# Altium schematic sheet All-in-one-PCB.SchDoc
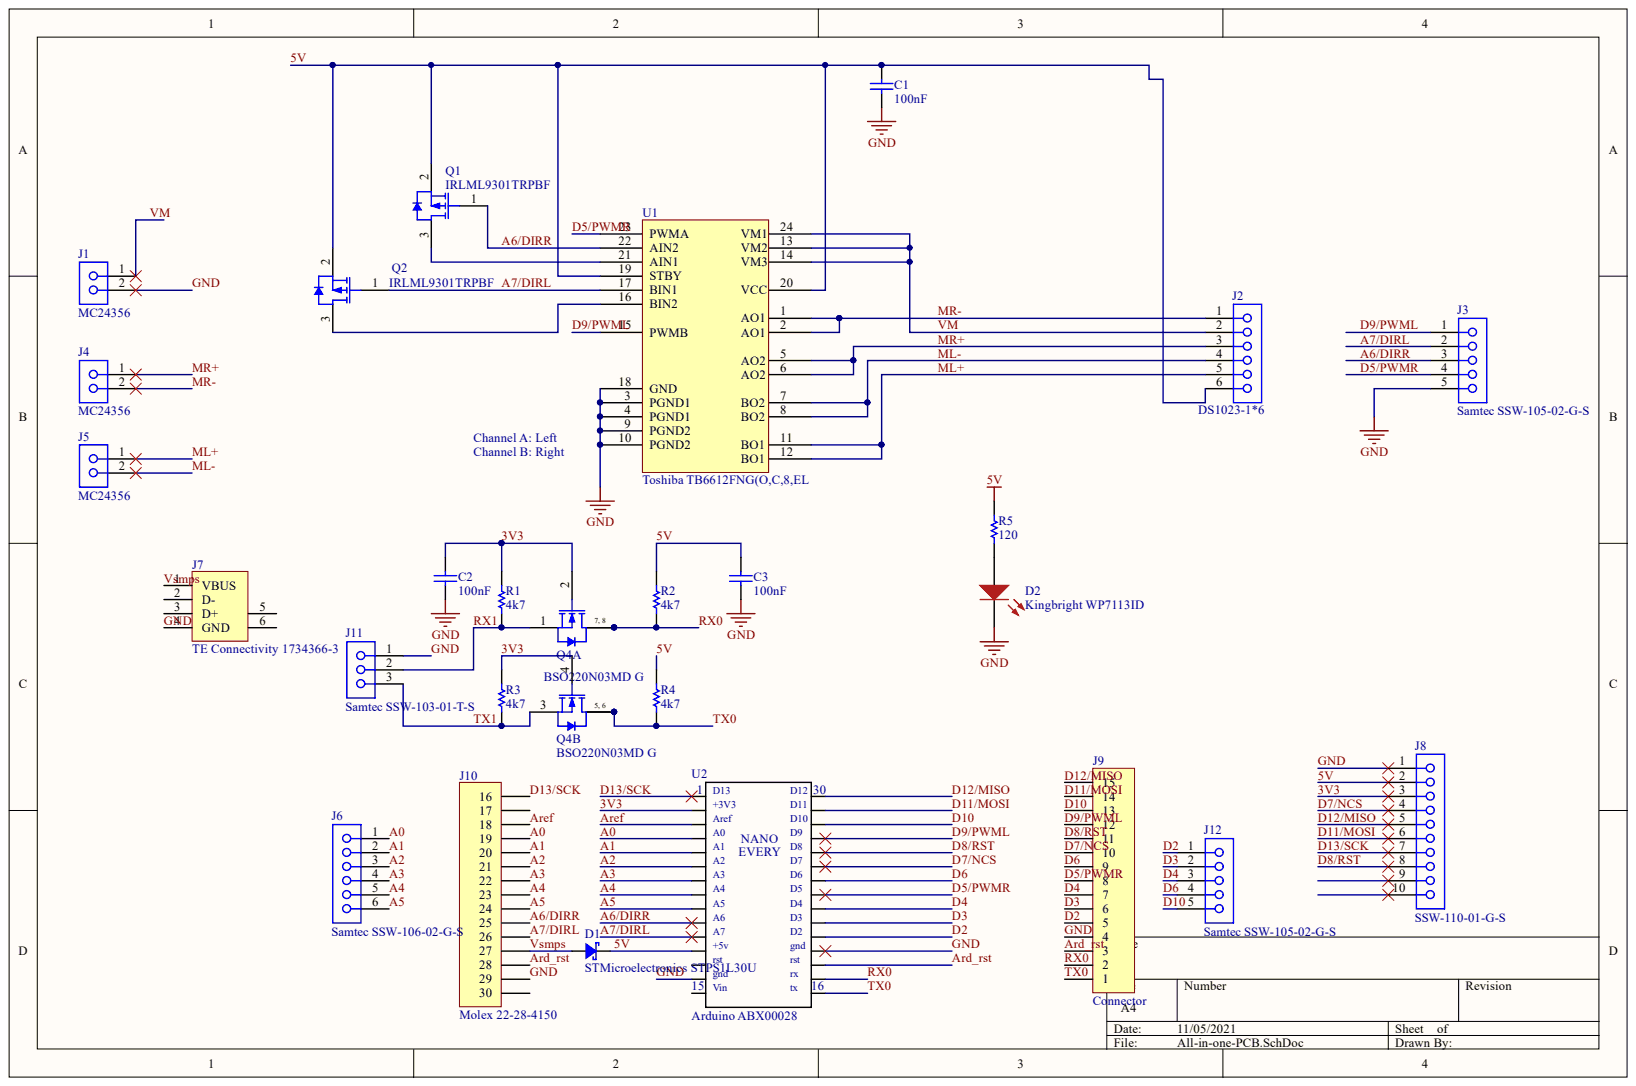
[diagram p0: All-in-one-PCB.SchDoc]

## Parts
C1  100nF  [PCB-57hlz3pi1rl6cavaka4r-1]
C2  100nF  [PCB-57hlz3pi1rl6cavaka4r-1]
C3  100nF  [PCB-57hlz3pi1rl6cavaka4r-1]
D1  STMicroelectronics STPS1L30U  [STPS1L30U]
D2  Kingbright WP7113ID  MAnufacturer: Kingbright  [PCB-jefhst57b79kpwmo8nrj-1]
J1  MC24356  [Multicomp MC24356]
J2  DS1023-1*6  [SSW-106-02-G-S]
J3  Samtec SSW-105-02-G-S  [SSW-105-02-G-S]
J4  MC24356  [Multicomp MC24356]
J5  MC24356  [Multicomp MC24356]
J6  Samtec SSW-106-02-G-S  [SSW-106-02-G-S]
J7  TE Connectivity 1734366-3  [PCB-wbedblczgi94a8su0lrn-1]
J8  SSW-110-01-G-S  [SMTC-SSW-110-01-G-S]
J9  Connector  [HDR_1x15_2.54MM]
J10  Molex 22-28-4150  Manufacturer: Molex  [HDR_1x15_2.54MM]
J11  Samtec SSW-103-01-T-S  [SSW-103-01-T-S]
J12  Samtec SSW-105-02-G-S  [SSW-105-02-G-S]
Q1  IRLML9301TRPBF  [PCB-9islral7dke0ceot50ut-1]
Q2  IRLML9301TRPBF  [PCB-9islral7dke0ceot50ut-1]
Q4  BSO220N03MD G  [INF-PG-DSO-8-16_N]  (parts A,B)
R1  4k7  [RESC2013X55X40NL15T20]
R2  4k7  [RESC2013X55X40NL15T20]
R3  4k7  [RESC2013X55X40NL15T20]
R4  4k7  [RESC2013X55X40NL15T20]
R5  120  [RESC2013X55X40NL15T20]
U1  Toshiba TB6612FNG(O,C,8,EL  Manufacturer Part Number: TB6612FNG(O,C,8,EL  [PCB-j6inoi7yxibl7naj9frb-1]
U2  Arduino ABX00028  [PCB-2u3ma5hivgecccoh3tao-1]

## Nets
3V3: C2.2 J8.3 Q4.2 Q4.4 R1.2 R3.2 U2.2
5V: C1.2 C3.2 D1.2 J2.6 J8.2 Q1.2 Q2.2 R2.2 R4.2 R5.2 U1.19(STBY) U1.20(VCC) U2.12
A0: J6.1 J10.4 U2.4
A1: J6.2 J10.5 U2.5
A2: J6.3 J10.6 U2.6
A3: J6.4 J10.7 U2.7
A4: J6.5 J10.8 U2.8
A5: J6.6 J10.9 U2.9
A6/DIRR: J3.3 J10.10 Q1.1 U1.22(AIN2) U2.10
A7/DIRL: J3.2 J10.11 Q2.1 U1.17(BIN1) U2.11
ARD_RST: J9.3 J10.13 U2.18
AREF: J10.3 U2.3
D2: J9.5 J12.1 U2.20
D3: J9.6 J12.2 U2.21
D4: J9.7 J12.3 U2.22
D5/PWMR: J3.4 J9.8 U1.23(PWMA) U2.23
D6: J9.9 J12.4 U2.24
D7/NCS: J8.4 J9.10 U2.25
D8/RST: J8.8 J9.11 U2.26
D9/PWML: J3.1 J9.12 U1.15(PWMB) U2.27
D10: J9.13 J12.5 U2.28
D11/MOSI: J8.6 J9.14 U2.29
D12/MISO: J8.5 J9.15 U2.30
D13/SCK: J8.7 J10.1 U2.1
GND: C1.1 C2.1 C3.1 D2.2 J1.2 J3.5 J7.4(GND) J8.1 J9.4 J10.14 J11.1 U1.3(PGND1) U1.4(PGND1) U1.9(PGND2) U1.10(PGND2) U1.18(GND) U2.14 U2.19
ML+: J2.5 J5.1 U1.11(BO1) U1.12(BO1)
ML-: J2.4 J5.2 U1.7(BO2) U1.8(BO2)
MR+: J2.3 J4.1 U1.5(AO2) U1.6(AO2)
MR-: J2.1 J4.2 U1.1(AO1) U1.2(AO1)
RX0: J9.2 Q4.7 Q4.8 R2.1 U2.17
RX1: J11.2 Q4.1 R1.1
TX0: J9.1 Q4.5 Q4.6 R4.1 U2.16
TX1: J11.3 Q4.3 R3.1
VM: J1.1 J2.2 U1.13(VM2) U1.14(VM3) U1.24(VM1)
VSMPS: D1.1 J7.1(VBUS) J10.12
NetD2_1: D2.1 R5.1
NetQ1_3: Q1.3 U1.21(AIN1)
NetQ2_3: Q2.3 U1.16(BIN2)

Not connected: J7 2(D-) 3(D+) 5 6; J8 9 10; J10 2 15; U2 13 15

## Library data of the parts
C1-C3 = CMP-437c8a7497fb9cbb-40: CC Series 0805 0.1 uF 50 V ±10 % Tolerance X7R SMT Multilayer Ceramic Capacitor; Capacitance: 100 nF; Case/Package: 0805; Component Kind: Standard; Library Name: CommunityVault
D1 = CMP-231fea11f4bbfcd8-3: case, current, rectifier, reflow, temp, package, process, max, leaded, diode, Diodes, compatible, Semiconductors and ...; Component Kind: Standard; Library Name: FavoritesLibrary
D2 = CMP-f9af30a89dfb2668-2: Red T-1 3/4 5 mm 30° 50 mcd 2.2 V Tinted Solid State LED Lamp Through Hole; Forward Current: 20 mA; Forward Voltage: 2 V; Lead-Free Status: Lead Free; Wavelength: 627 nm; Color: Red; Component Kind: Standard; Library Name: CommunityVault; Manufacturer Part Number: WP7113ID; OctopartID: f9af30a89dfb2668; Octopart URL: http://octopart.com/wp7113id-kingbright-868658; RoHS: Compliant; Viewing Angle: 30 °
J1 J4 J5 = CMP-19300950-8: Wire-To-Board Terminal Block, 5 mm, 2 Ways, 24 AWG, 16 AWG, Screw; Number of Contacts: 2; Component Kind: Standard; Contact Material: Brass; Contact Plating: Tin; Library Name: CommunityVault; Manufacturer Part Number: MC24356; Mounting Style: PCB; OctopartID: 7d95b4e213eaa252; Octopart URL: http://octopart.com/mc24356-multicomp-8211225; RoHS: Compliant
J2 J6 = CMP-678876-11: Samtec SSW Series 2.54mm 6 Way 1 Row Straight PCB Socket Through Hole Board to Board; Contact Resistance: 0.01 Ω; Gender: Female; Lead-Free Status: Lead Free; Number of Contacts: 6; Number of Mating Cycles: 100; Pin Pitch: 0.00254 m; Color: Black; Component Kind: Standard; Contact Material: Phosphor Bronze; Contact Plating: Gold; Housing Color: Black; Housing Material: Plastic; Library Name: CommunityVault; Manufacturer Part Number: SSW-106-02-G-S; Mounting Style: Through Hole; Number of Positions: 6; Number of Rows: 1; OctopartID: 81fa48f421455e3d; Octopart Url: https://octopart.com/ssw-106-02-g-s-samtec-296409; Order Code (RS Components): 765-5672; Packaging: Tube; REACH SVHC Compliance: No SVHC; RoHS: Yes; Size-Height: 0.00851 m; Size-Length: 0.01575 m; Size-Width: 0.00241 m
J3 J12 = CMP-2729ee278797f8ee-3: Conn Socket Strip SKT 5 POS 2.54mm Solder ST Thru-Hole; Lead-Free Status: Lead Free; Number of Contacts: 5; Number of Mating Cycles: 100; Color: Black; Component Kind: Standard; Contact Material: Phosphor Bronze; Contact Plating: Gold; Housing Color: Black; Housing Material: Plastic; Library Name: CommunityVault; Manufacturer Part Number: SSW-105-02-G-S; Mounting Style: Through Hole; Number of Positions: 5; Number of Rows: 1; OctopartID: 2729ee278797f8ee; Octopart URL: http://octopart.com/ssw-105-02-g-s-samtec-296408; Packaging: Tube; Pin Pitch: 2.54 mm; RoHS: Yes; Size-Height: 8.51 mm; Size-Length: 13.2 mm; Size-Width: 2.41 mm
J7 = 1734366-3: Conn USB 2.0 Type A RCP 4 POS Solder ST Thru-Hole 4 Terminal 1 Port Tray; ELV Compliant: Compliant; Gender: Female; Lead-Free Status: Lead Free; Component Kind: Standard; Contact Plating: Gold Flash, Gold Flash over Nickel; Housing Color: Blue; Housing Material: Thermoplastic; Library Name: Octopart library; Lifecycle Status: Active; Manufacturer Part Number: 1734366-3; OctopartID: 27c8ec666f97f80f; Octopart URL: http://octopart.com/1734366-3-te+connectivity-44330297; Packaging: Tray; RoHS: Compliant
J8 = CMP-678526-2: SOCKET, Through-hole, Vertical, -55 to 125 degC, 2.54 mm Pitch, 10-Pin Female, RoHS; Contact Resistance: 0.01 Ω; Lead-Free Status: Lead Free; Number of Contacts: 10; Number of Mating Cycles: 100; Pin Pitch: 0.00254 m; Color: Black; Component Kind: Standard; Contact Material: Phosphor Bronze; Contact Plating: Gold; Gender: Female; Housing Color: Black; Housing Material: Plastic; Library Name: CommunityVault; Manufacturer Part Number: SSW-110-01-G-S; Mounting Style: Through Hole; Number of Positions: 10; Number of Rows: 1; OctopartID: 1018d916b1ffcfdf; Octopart Url: https://octopart.com/ssw-110-01-g-s-samtec-296065; Packaging: Tube; REACH SVHC Compliance: No SVHC; RoHS: Yes; Size-Height: 0.00851 m; Size-Length: 0.02591 m; Size-Width: 0.00241 m
J9 = CMP-4502544-3: Headers & Wire Housings 15P VERT HEADER Sn; Manufacturer: Molex; Manufacturer Part Number: 0022284150; Current Rating: 4.0 A; Gender: Male; Glow Wire Compliant: Non-Compliant; Lead-Free Status: Contains Lead; Number of Circuits: 15; Pin Pitch: 0.00254 m; Voltage Rating (DC): 250.0 V; Category: Headers & Wire Housings; Component Kind: Standard; Contact Material: Phosphor Bronze; Contact Plating: Tin; Flammability Rating: UL 94 V-0; Halogen Free Status: Not Low Halogen; Housing Color: Black; Housing Material: Nylon; Library Name: CommunityVault; Lifecycle Status: Active; Mounting Style: Through Hole; Number of Contacts: 15; Number of Positions: 15; Number of Rows: 1; OctopartID: cc960598d7571351; Octopart Url: https://octopart.com/22-28-4150-molex-278183; Orientation: Vertical; Packaging: Bulk; RoHS: Compliant; Size-Height: 0.00229 m; Size-Length: 0.0381 m; Size-Width: 0.00249 m; Supplier 1: Mouser; Supplier Part Number 1: 538-22-28-4150
J10 = CMP-4502544-3: Headers & Wire Housings 15P VERT HEADER Sn; Manufacturer Part Number: 0022284150; Current Rating: 4.0 A; Gender: Male; Glow Wire Compliant: Non-Compliant; Lead-Free Status: Contains Lead; Number of Circuits: 15; Pin Pitch: 0.00254 m; Voltage Rating (DC): 250.0 V; Category: Headers & Wire Housings; Component Kind: Standard; Contact Material: Phosphor Bronze; Contact Plating: Tin; Flammability Rating: UL 94 V-0; Halogen Free Status: Not Low Halogen; Housing Color: Black; Housing Material: Nylon; Library Name: CommunityVault; Lifecycle Status: Active; Mounting Style: Through Hole; Number of Contacts: 15; Number of Positions: 15; Number of Rows: 1; OctopartID: cc960598d7571351; Octopart Url: https://octopart.com/22-28-4150-molex-278183; Orientation: Vertical; Packaging: Bulk; RoHS: Compliant; Size-Height: 0.00229 m; Size-Length: 0.0381 m; Size-Width: 0.00249 m; Supplier 1: Mouser; Supplier Part Number 1: 538-22-28-4150
J11 = CMP-0c8bc585acacd34c-1: Conn Socket Strip SKT 3 POS 2.54mm Solder ST Top Entry Thru-Hole Tube; Gender: Female; Lead-Free Status: Lead Free; Lead Length: 2.64 mm; Number of Contacts: 3; Voltage Rating (AC): 465 V; Voltage Rating (DC): 655 V; Component Kind: Standard; Contact Material: Phosphor Bronze; Contact Plating: Tin; Housing Color: Black; Housing Material: Plastic; Library Name: FavoritesLibrary; Manufacturer Part Number: SSW-103-01-T-S; Mounting Style: Through Hole; Number of Positions: 3; Number of Rows: 1; OctopartID: 0c8bc585acacd34c; Octopart URL: https://octopart.com/ssw-103-01-t-s-samtec-296257; Operating Temperature: 105 °C (max); Packaging: Tube; Pin Pitch: 2.54 mm; REACH SVHC Compliance: No SVHC; RoHS: Compliant; Size-Height: 8.51 mm; Size-Length: 8.13 mm; Size-Width: 2.41 mm
Q1 Q2 = CMP-6db1a5a70cd2b276-1: Single N-Channel 30 V 1.3 W 4.8 nC Hexfet Power Mosfet Surface Mount - MICRO-3; Continuous Drain Current (Ids): -3.60 A; Drain to Source Voltage (Vds): -30.0 V; Lead-Free Status: Lead Free; Polarity: P-Channel; Power Dissipation: 1.30 W; Component Kind: Standard; Lifecycle Status: Active; Manufacturer Part Number: IRLML9301TRPBF; Mounting Style: Surface Mount; Number of Pins: 3; OctopartID: 6db1a5a70cd2b276; Octopart URL: http://octopart.com/irlml9301trpbf-international+rectifier-18629637; Packaging: Cut Tape (CT); Part Family: IRLML9301; REACH SVHC Compliance: No SVHC; RoHS: Compliant
  Q1: Library Name: CommunityVault
  Q2: Library Name: FavoritesLibrary
Q4 = CMP-3789839-2: N-Channel OptiMOS 3 M-Series Power-MOSFET, 30 V VDS, 7.7 A ID, -55 to 150 degC, PG-DSO-8-16, Reel, Green; Manufacturer Part Number: BSO220N03MDGXUMA1; Lead-Free Status: Lead Free; Polarity: Dual N-Channel; Power Dissipation: 2.0 W; Component Kind: Standard; Library Name: CommunityVault; Number of Pins: 8; OctopartID: 7627bfed94a8a9e0; Octopart Url: https://octopart.com/bso220n03md+g-infineon-12634089; REACH SVHC Compliance: No SVHC; RoHS: Compliant
R1-R5 = CMP-b013793a15d56fd4-2: Res Thick Film 0805 1K Ohm 1% 1/8W ±100ppm/°C Molded SMD SMD Paper Tape on Plastic Reel; Power Rating: 125 mW; Temperature Coefficient: -100 ppm/°C to 100 ppm/°C; Voltage Rating (DC): 150 V; Component Kind: Standard; Library Name: CommunityVault; Mounting Style: Surface Mount; Operating Temperature: -55.0 °C to 155 °C; Packaging: Tape & Reel (TR), Cut Tape (CT); REACH SVHC Compliance: No SVHC; Simulation: SIM-vjisq6q3u7vp6b0mgwb9-1
U1 = CMP-19a5d1d8b246c662-4: Stepping Motor Driver Ic Unipolor Copper Wire 8"; Component Kind: Standard; Library Name: CommunityVault; OctopartID: 19a5d1d8b246c662; Octopart URL: http://octopart.com/tb6612fng%28o%2Cc%2C8%2Cel-toshiba-24612485
U2 = CMP-a759684c3e55789e-7: Arduino Nano Every; Component Kind: Standard; Library Name: CommunityVault
